# Altium schematic sheet E2PROM.SchDoc(E2PROM)
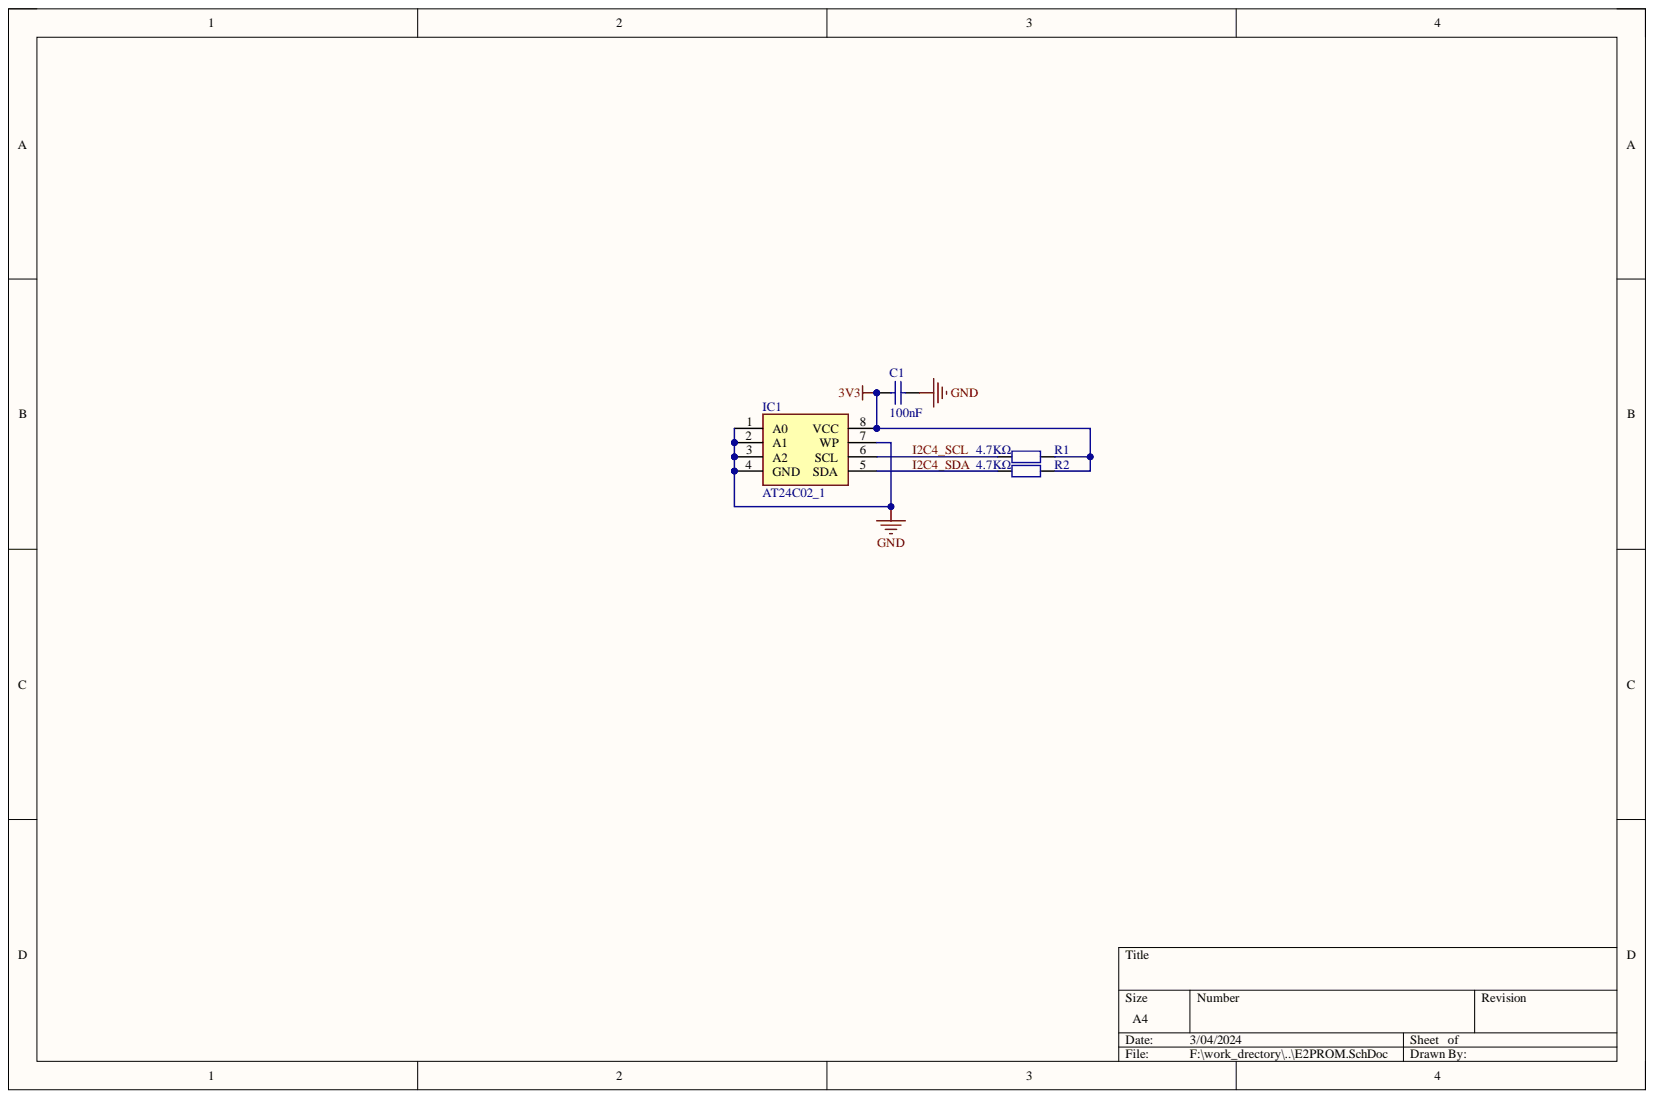
[diagram p0: E2PROM.SchDoc]

## Parts
C1  100nF  [0402_C]
IC1  AT24C02_1  [SOP-8]
R1  4.7KΩ  [0402_R]
R2  4.7KΩ  [0402_R]

## Nets
3V3: C1.2 IC1.8(VCC) R1.1 R2.1
GND: C1.1 IC1.1(A0) IC1.2(A1) IC1.3(A2) IC1.4(GND) IC1.7(WP)
I2C4_SCL: IC1.6(SCL) R1.2
I2C4_SDA: IC1.5(SDA) R2.2

## Library data of the parts
C1 = Cap: 贴片电容; Component Kind: Standard; ComponentLink1Description: 供应商链接; ComponentLink1URL: http://www.szlcsc.com/product/details_1875.html; Library Name: MY_LIB.SchLib; Package: 0402; Supplier: LC; SuppliersPartNumber: C1523
IC1 = AT24C02_1: C688857; Manufacturer_Name: Microchip; Manufacturer_Part_Number: AT24C02D-MAHM-E; Arrow Part Number: AT24C02D-MAHM-E; Arrow Price/Stock: https://www.arrow.com/en/products/at24c02d-mahm-e/microchip-technology?region=nac; Component Kind: Standard; Datasheet Link: https://componentsearchengine.com/Datasheets/1/AT24C02D-MAHM-E.pdf; Height: 0.6mm; Library Name: MY_LIB.SchLib; Mouser Part Number: 579-AT24C02D-MAHM-E; Mouser Price/Stock: https://www.mouser.co.uk/ProductDetail/Microchip-Technology-Atmel/AT24C02D-MAHM-E?qs=CM3LqkkxGd7QnJRv2iJ8hQ%3D%3D
R1 R2 = 0402 4.7KΩ (4701) 1%: 贴片电阻; Component Kind: Standard; ComponentLink1Description: 供应商链接; ComponentLink1URL: http://www.szlcsc.com/product/details_26643.html; Library Name: Miscellaneous Devices LC.IntLib; Package: 0402; Supplier: LC; SuppliersPartNumber: C25900
